# Altium schematic sheet SpeedLEDs.SchDoc(SpeedLEDs)
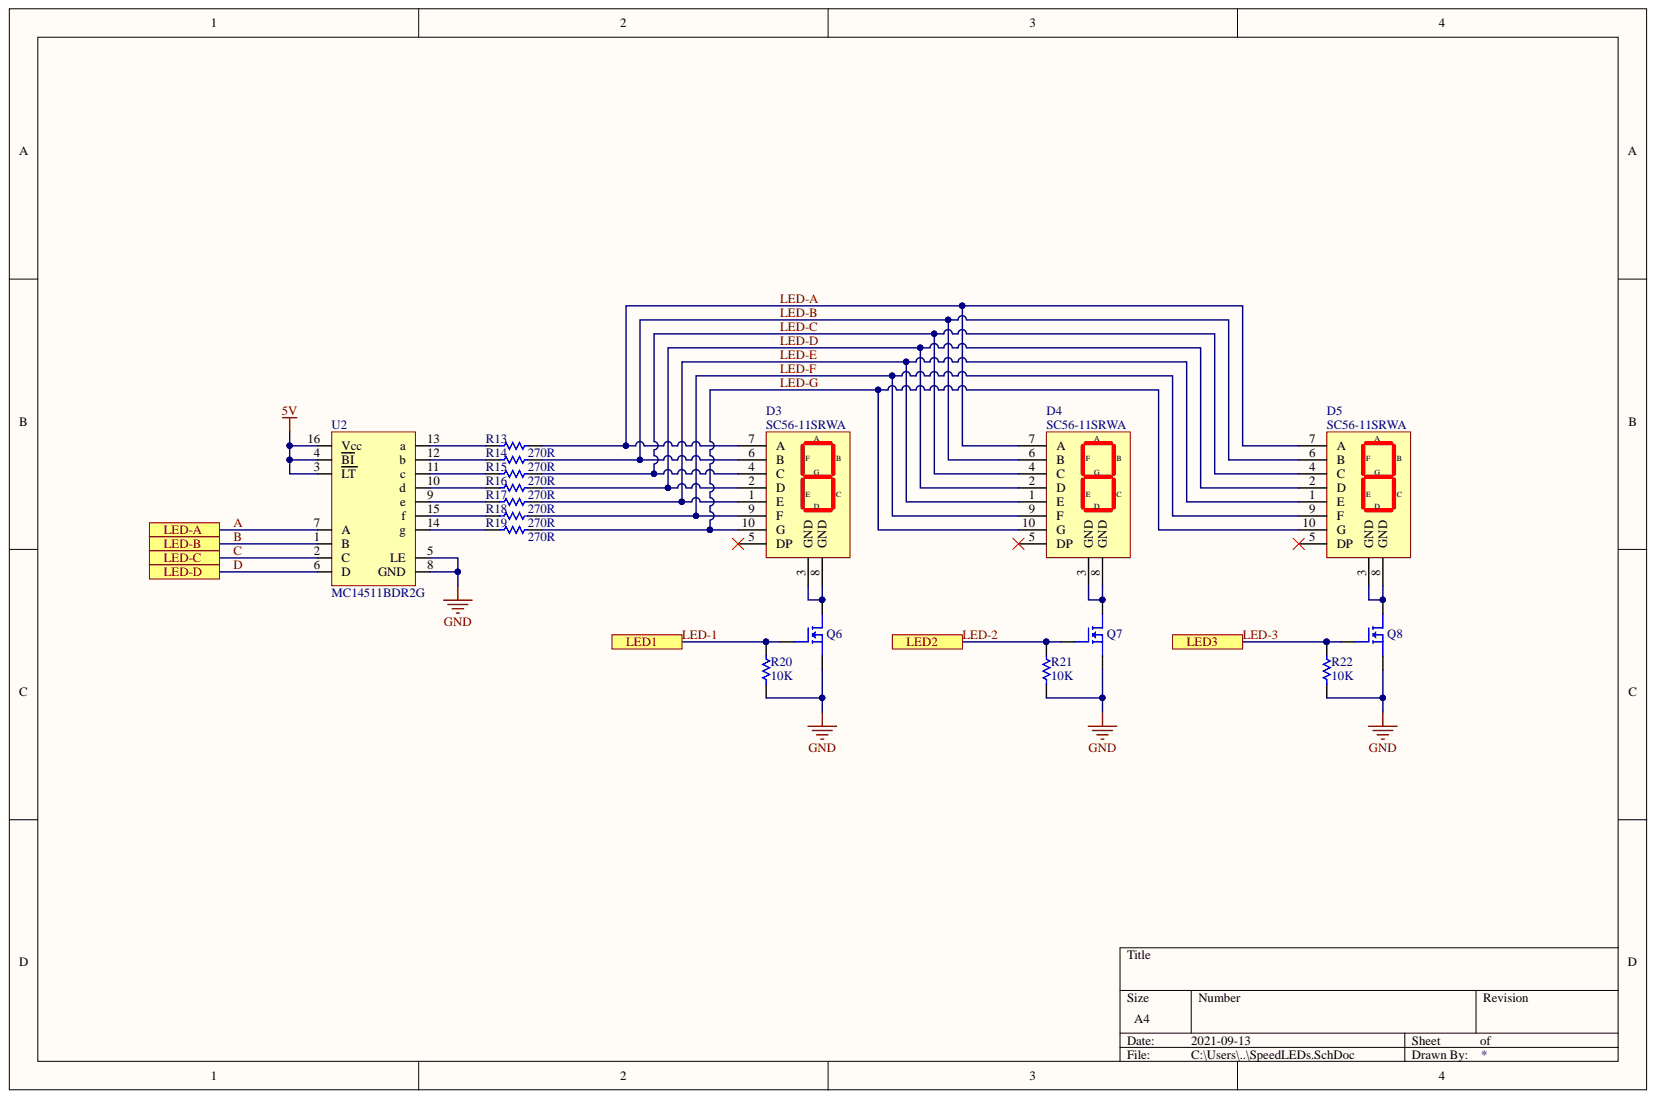
[diagram p0: SpeedLEDs.SchDoc]

## Parts
D3  SC56-11SRWA  [7-seg]
D4  SC56-11SRWA  [7-seg]
D5  SC56-11SRWA  [7-seg]
Q6  DMN6140L-13DICT-ND  [SOT-23-3]  (value not drawn)
Q7  DMN6140L-13DICT-ND  [SOT-23-3]  (value not drawn)
Q8  DMN6140L-13DICT-ND  [SOT-23-3]  (value not drawn)
R13  270R  [RES-0603]
R14  270R  [RES-0603]
R15  270R  [RES-0603]
R16  270R  [RES-0603]
R17  270R  [RES-0603]
R18  270R  [RES-0603]
R19  270R  [RES-0603]
R20  10K  [RES-0603]
R21  10K  [RES-0603]
R22  10K  [RES-0603]
U2  MC14511BDR2G  [SOIC16]

## Ports
LED1, LED2, LED3, LED-A, LED-B, LED-C, LED-D

## Nets
5V: U2.3(LT) U2.4(BI) U2.16(Vcc)
GND: Q6.3 Q7.3 Q8.3 R20.1 R21.1 R22.1 U2.5(LE) U2.8(GND)
A: U2.7(A) ; port LED-A
B: U2.1(B) ; port LED-B
C: U2.2(C) ; port LED-C
D: U2.6(D) ; port LED-D
LED-1: Q6.1 R20.2 ; port LED1
LED-2: Q7.1 R21.2 ; port LED2
LED-3: Q8.1 R22.2 ; port LED3
LED-A: D3.7(A) D4.7(A) D5.7(A) R13.2
LED-B: D3.6(B) D4.6(B) D5.6(B) R14.2
LED-C: D3.4(C) D4.4(C) D5.4(C) R15.2
LED-D: D3.2(D) D4.2(D) D5.2(D) R16.2
LED-E: D3.1(E) D4.1(E) D5.1(E) R17.2
LED-F: D3.9(F) D4.9(F) D5.9(F) R18.2
LED-G: D3.10(G) D4.10(G) D5.10(G) R19.2
NetD3_3: D3.3(GND) D3.8(GND) Q6.2
NetD4_3: D4.3(GND) D4.8(GND) Q7.2
NetD5_3: D5.3(GND) D5.8(GND) Q8.2
NetR13_1: R13.1 U2.13(a)
NetR14_1: R14.1 U2.12(b)
NetR15_1: R15.1 U2.11(c)
NetR16_1: R16.1 U2.10(d)
NetR17_1: R17.1 U2.9(e)
NetR18_1: R18.1 U2.15(f)
NetR19_1: R19.1 U2.14(g)

Not connected: D3 5(DP); D4 5(DP); D5 5(DP)

## Library data of the parts
D3-D5 = 7-segment: DISPLAY 7SEG 0.56" SGL RED 10DIP; Manufacturer: Kingbright; Wavelength: 640nm; Test Current: 20mA; Power Consumption: 75mW; Operating Supply Voltage: 1.85V; Number of Characters: 1; Luminous Intensity: 34mcd; Element Configuration: Common Cathode; Width: 0.5inch; Supplier Part Number 1: 754-1467-5-ND; Supplier 1: Digi-Key; Stock 1: 32391; RoHS Compliant: Yes; REACH SVHC: No SVHC; Pricing 1: 1=1.96, 10=1.24, 100=0.9177, 500=0.84334, 1000=0.702 (USD); Packaging: Bulk; Package Quantity: 40; Min Operating Temperature: -40°C; Max Power Dissipation: 75mW; Max Operating Temperature: 85°C; Manufacturer Part Number: SC56-11SRWA; Library Name: InverterSCHLib.SchLib; Lead Free: Lead Free; Illumination Color: Red; Height: 0.75inch; Forward Voltage: 1.85V; Forward Current: 20mA; Display Type: Segment; ComponentLink3URL: http://www.kingbrightusa.com/images/catalog/SPEC/SC56-11SRWA.pdf?src-supplier=Future+Electronics; ComponentLink3Description: http://www.kingbrightusa.com/images/catalog/SPEC/SC56-11SRWA.pdf?src-supplier=Future+Electronics; ComponentLink2URL: http://www.mouser.com/ds/2/216/SC56-11SRWA-66719.pdf?src-supplier=Mouser; ComponentLink2Description: http://www.mouser.com/ds/2/216/SC56-11SRWA-66719.pdf?src-supplier=Mouser; ComponentLink1URL: http://www.farnell.com/datasheets/2047590.pdf?src-supplier=Element14; ComponentLink1Description: http://www.farnell.com/datasheets/2047590.pdf?src-supplier=Element14; Component Kind: Standard; Color: Red; Case/Package: DIP
Q6-Q8 = N-FET-60V-1.6A: MOSFET N-CH 60V 1.6A SOT23; Manufacturer: Diodes; Rds On Max: 140mR; Max Power Dissipation: 700mW; Input Capacitance: 315pF; Supplier Part Number 1: DMN6140L-13DIDKR-ND; Supplier 1: Digi-Key; Stock 1: 274948; Pricing 1: 1=0.34, 10=0.256, 25=0.224, 100=0.1217, 250=0.12104, 500=0.09926, 1000=0.07365, 2500=0.06468, 5000=0.05924 (USD); Packaging: Cut Tape; Package Quantity: 10000; Mount: Surface Mount; Min Operating Temperature: -55°C; Max Operating Temperature: 150°C; Manufacturer Part Number: DMN6140L-13; Library Name: InverterSCHLib.SchLib; Drain to Source Voltage (Vdss): 60V; Continuous Drain Current (ID): 1.6A; ComponentLink1URL: https://www.diodes.com/assets/Datasheets/DMN6140L.pdf?src-supplier=Digi-Key; ComponentLink1Description: https://www.diodes.com/assets/Datasheets/DMN6140L.pdf?src-supplier=Digi-Key; Component Kind: Standard; Case/Package: TO-236-3
R13-R19 = 0603-270R: RES SMD 270 OHM 0.5% 1/10W 0603; Manufacturer Part Number: RT0603DRE07270RL; Manufacturer: Yageo; Voltage Rating (DC): 50V; Voltage Rating: 75V; Temperature Coefficient: 50ppm/°C; Power Rating: 100mW; Width: 0.031inch; Tolerance: 0.5%; Termination: SMD/SMT; Supplier Part Number 1: 311-2504-1-ND; Supplier 1: Digi-Key; Stock 1: 5811; Series: RT; RoHS Compliant: Yes; Resistor Type: Thin Film; Resistance: 270R; Pricing 1: 1=0.1, 10=0.092, 100=0.0352, 1000=0.01549, 2500=0.01338 (USD); Packaging: Tape and Reel; Package Quantity: 5000; Number of Terminations: 2; Min Operating Temperature: -55°C; Max Power Dissipation: 100mW; Max Operating Temperature: 155°C; Library Name: InverterSCHLib.SchLib; Length: 0.063inch; Lead Free: Lead Free; Height: 0.022inch; Features: Moisture Resistant; ComponentLink1URL: http://www.yageo.com/NewPortal/yageodocoutput?fileName=/pdf/R-Chip/PYu-RT_1-to-0.05_RoHS_L_5.pdf&src-supplier=Digi-Key; ComponentLink1Description: http://www.yageo.com/NewPortal/yageodocoutput?fileName=/pdf/R-Chip/PYu-RT_1-to-0.05_RoHS_L_5.pdf&src-supplier=Digi-Key; Component Kind: Standard; Case Code (Metric): 1608; Case Code (Imperial): 0603; Case/Package: 0603
R20-R22 = 0603-10KR: RES SMD 10K OHM 5% 1/10W 0603; Manufacturer Part Number: RC0603JR-0710KL; Manufacturer: Yageo; Voltage Rating (DC): 50V; Temperature Coefficient: 100ppm/°C; Resistance: 10kR; Power Rating: 100mW; Width: 0.031inch; Voltage Rating: 50V; Tolerance: 5%; Termination: SMD/SMT; Supplier Part Number 1: 311-10KGRDKR-ND; Supplier 1: Digi-Key; Stock 1: 3006558; REACH SVHC: Unknown; Radiation Hardening: No; Pricing 1: 1=0.1, 10=0.019, 100=0.0077, 1000=0.00347, 2500=0.00301 (USD); Packaging: Tape and Reel; Number of Terminations: 2; Number of Pins: 2; Min Operating Temperature: -55°C; Military Standard: Not; Max Power Dissipation: 100mW; Max Operating Temperature: 155°C; Library Name: InverterSCHLib.SchLib; Length: 0.063inch; Lead Free: Lead Free; Height - Seated (Max): 0.022inch; Features: Moisture Resistant; Depth: 0.8mm; Contact Plating: Tin,Matte; Composition: Thick Film; ComponentLink1URL: http://www.yageo.com/documents/recent/PYu-RC_Group_51_RoHS_L_10.pdf?src-supplier=Digi-Key; ComponentLink1Description: http://www.yageo.com/documents/recent/PYu-RC_Group_51_RoHS_L_10.pdf?src-supplier=Digi-Key; Component Kind: Standard; Case Code (Metric): 1608; Case Code (Imperial): 0603; Case/Package: 0603
U2 = MC14511BDR2G: IC DRVR 7 SEGMENT 16SOIC; Manufacturer: ON Semiconductor; Turn-On Delay Time: 1440ns; Quiescent Current: 20uA; Polarity: Non-Inverting; Operating Supply Current: 10uA; Number of Outputs: 7; Number of Inputs: 4; Number of Elements: 1; Min Supply Voltage: 3V; Max Supply Voltage: 18V; Low Level Output Current: 4.2mA; Logic Function: Decoder,Latch; High Level Output Current: -25mA; Display Type: LCD; Technology: CMOS; Supplier Part Number 1: MC14511BDR2GOSDKR-ND; Supplier 1: Digi-Key; Stock 1: 82; Series: -; RoHS Compliant: Yes; Radiation Hardening: No; Propagation Delay: 1440ns; Pricing 1: 1=0.63, 10=0.555, 25=0.5216, 100=0.4256, 250=0.39532, 500=0.33644, 1000=0.27772 (USD); Packaging: Tape and Reel; Package Quantity: 2500; Number of Pins: 16; Number of Output Lines: 7; Number of Input Lines: 4; Number of Bits: 4; Mount: Surface Mount; Min Operating Temperature: -55°C; Max Operating Temperature: 125°C; Manufacturer Part Number: MC14511BDR2G; Library Name: InverterSCHLib.SchLib; Lead Free: Lead Free; Halogen Free: Halogen Free; ComponentLink2URL: http://www.onsemi.com/pub_link/Collateral/MC14511B-D.PDF?src-supplier=Digi-Key; ComponentLink2Description: http://www.onsemi.com/pub_link/Collateral/MC14511B-D.PDF?src-supplier=Digi-Key; ComponentLink1URL: https://www.verical.com/server-webapp/html/getDatasheet?partID=319336&src-supplier=Verical; ComponentLink1Description: https://www.verical.com/server-webapp/html/getDatasheet?partID=319336&src-supplier=Verical; Component Kind: Standard; Case/Package: SOIC
